# Altium schematic sheet REUN.468349.001_PSU_Board_12B_Interface.SchDoc
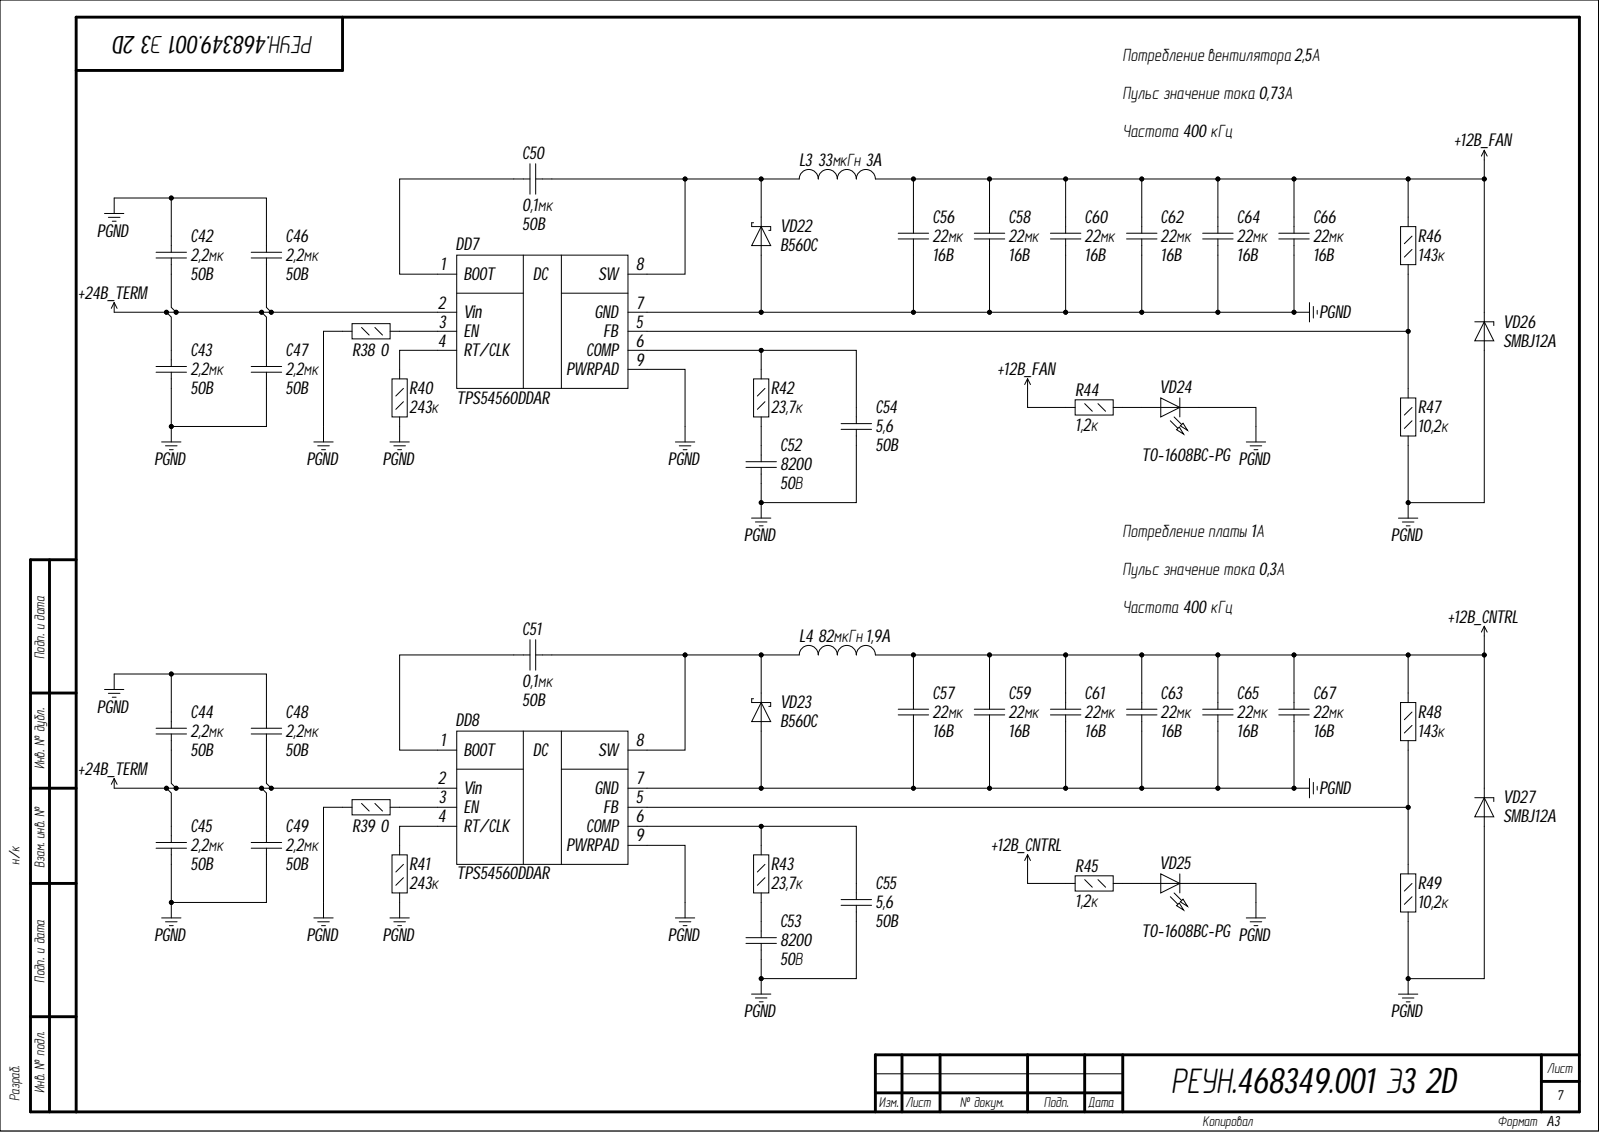
[diagram p0: REUN.468349.001_PSU_Board_12B_Interface.SchDoc]

## Parts
C42  2,2мк  Voltage: 50B  [C1206]
C43  2,2мк  Voltage: 50B  [C1206]
C44  2,2мк  Voltage: 50B  [C1206]
C45  2,2мк  Voltage: 50B  [C1206]
C46  2,2мк  Voltage: 50B  [C1206]
C47  2,2мк  Voltage: 50B  [C1206]
C48  2,2мк  Voltage: 50B  [C1206]
C49  2,2мк  Voltage: 50B  [C1206]
C50  0,1мк  Voltage: 50B  [C0805]
C51  0,1мк  Voltage: 50B  [C0805]
C52  8200  Voltage: 50В  [C0805]
C53  8200  Voltage: 50В  [C0805]
C54  5,6  Voltage: 50B  [C0805]
C55  5,6  Voltage: 50B  [C0805]
C56  22мк  Voltage: 16B  [C1210]
C57  22мк  Voltage: 16B  [C1210]
C58  22мк  Voltage: 16B  [C1210]
C59  22мк  Voltage: 16B  [C1210]
C60  22мк  Voltage: 16B  [C1210]
C61  22мк  Voltage: 16B  [C1210]
C62  22мк  Voltage: 16B  [C1210]
C63  22мк  Voltage: 16B  [C1210]
C64  22мк  Voltage: 16B  [C1210]
C65  22мк  Voltage: 16B  [C1210]
C66  22мк  Voltage: 16B  [C1210]
C67  22мк  Voltage: 16B  [C1210]
DD7  TPS54560DDAR  [SO Powerpad8]
DD8  TPS54560DDAR  [SO Powerpad8]
L3  value 33мкГн  Rated Current: 3A  [IND_12.5x12.5]  (hidden comment: Inductor)
L4  value 82мкГн  Rated Current: 1,9A  [IND_12.5x12.5]  (hidden comment: Inductor)
R38  value 0  [R0805]  (hidden comment: Resistor_0,125Вт)
R39  value 0  [R0805]  (hidden comment: Resistor_0,125Вт)
R40  value 243к  [R0805]  (hidden comment: Resistor_0,125Вт)
R41  value 243к  [R0805]  (hidden comment: Resistor_0,125Вт)
R42  value 23,7к  [R0805]  (hidden comment: Resistor_0,125Вт)
R43  value 23,7к  [R0805]  (hidden comment: Resistor_0,125Вт)
R44  value 1,2к  [R0805]  (hidden comment: Resistor_0,125Вт)
R45  value 1,2к  [R0805]  (hidden comment: Resistor_0,125Вт)
R46  value 143к  [R0805]  (hidden comment: Resistor_0,125Вт)
R47  value 10,2к  [R0805]  (hidden comment: Resistor_0,125Вт)
R48  value 143к  [R0805]  (hidden comment: Resistor_0,125Вт)
R49  value 10,2к  [R0805]  (hidden comment: Resistor_0,125Вт)
VD22  B560C  [SMC]
VD23  B560C  [SMC]
VD24  TO-1608BC-PG  [L_0603_GREEN]
VD25  TO-1608BC-PG  [L_0603_GREEN]
VD26  SMBJ12A  [SMB]
VD27  SMBJ12A  [SMB]

## Nets
+12B_CNTRL: C57.2 C59.2 C61.2 C63.2 C65.2 C67.2 L4.2 R45.2 R48.2 VD27.1
+12B_FAN: C56.2 C58.2 C60.2 C62.2 C64.2 C66.2 L3.2 R44.2 R46.2 VD26.1
+24B_TERM: C42.1 C43.2 C44.1 C45.2 C46.1 C47.2 C48.1 C49.2 DD7.2(Vin) DD8.2(Vin)
PGND: C42.2 C43.1 C44.2 C45.1 C46.2 C47.1 C48.2 C49.1 C52.1 C53.1 C54.1 C55.1 C56.1 C57.1 C58.1 C59.1 C60.1 C61.1 C62.1 C63.1 C64.1 C65.1 C66.1 C67.1 DD7.7(GND) DD7.9(PWRPAD) DD8.7(GND) DD8.9(PWRPAD) R38.2 R39.2 R40.1 R41.1 R47.1 R49.1 VD22.2 VD23.2 VD24.1 VD25.1 VD26.2 VD27.2
NetC50_1: C50.1 DD7.8(SW) L3.1 VD22.1
NetC50_2: C50.2 DD7.1(BOOT)
NetC51_1: C51.1 DD8.8(SW) L4.1 VD23.1
NetC51_2: C51.2 DD8.1(BOOT)
NetC52_2: C52.2 R42.1
NetC53_2: C53.2 R43.1
NetC54_2: C54.2 DD7.6(COMP) R42.2
NetC55_2: C55.2 DD8.6(COMP) R43.2
NetDD7_3: DD7.3(EN) R38.1
NetDD7_4: DD7.4(RT/CLK) R40.2
NetDD7_5: DD7.5(FB) R46.1 R47.2
NetDD8_3: DD8.3(EN) R39.1
NetDD8_4: DD8.4(RT/CLK) R41.2
NetDD8_5: DD8.5(FB) R48.1 R49.2
NetR44_1: R44.1 VD24.2
NetR45_1: R45.1 VD25.2

## Library data of the parts
C42-C49 = cap351: Конденсатор; Manufacture: Samsung; Part Name: MLCC; Part Number: CL31B225KBHNNNE; Database Table Name: Capacitors$; tga: 0.10%; TKE: X7R; Component Kind: Standard; id: cap351; Library Name: MOP44.DbLib; Value: 2,2мк
C50 C51 = cap141: Конденсатор; Manufacture: Murata; Part Name: Ceramic; Part Number: GRM21BR71H104KA01K; Database Table Name: Capacitors$; TKE: X7R; Component Kind: Standard; id: cap141; Library Name: MOP44.DbLib; Value: 0,1мк
C52 C53 = cap171: Конденсатор; Manufacture: Murata; Part Name: Ceramic; Part Number: GRM216R71H822KA01D; Database Table Name: Capacitors$; TKE: X7R; Component Kind: Standard; id: cap171; Library Name: MOP44.DbLib; Value: 8200
C54 C55 = cap355: Конденсатор; Manufacture: Samsung; Part Name: Ceramic; Part Number: CL21C5R6CBANNNC; Database Table Name: Capacitors$; tga: 0.05%; TKE: NP0; Component Kind: Standard; id: cap355; Library Name: MOP44.DbLib; Value: 5,6
C56-C67 = cap352: Конденсатор; Manufacture: Samsung; Part Name: MLCC; Part Number: CL32B226KOJNNNE; Database Table Name: Capacitors$; tga: 0.10%; TKE: X7R; Component Kind: Standard; id: cap352; Library Name: MOP44.DbLib; Value: 22мк
DD7 DD8 = pwr177: 5-A, Wide Input Range, Step-Down Converter; Manufacturer: Texas Instruments; Part Name: Step Down DC-DC Converter with Eco-mode; Current: 5A; Database Table Name: PowerTransducer$; Frequency: 100 kHz-25 MHz; Input Voltage: 4,5-60В; Output Voltage: 0,8B-58,8B; Component Kind: Standard; id: pwr177; Library Name: MOP44.DbLib; Part Number: TPS54560DDAR; Type: Impulse
L3 = ind80: EMI Suppression Filter; Manufacture: Epcos; Part Name: SMD Power Inductors; Part Number: B82477G4333M000; Database Table Name: Inductor$; Resistance: 0,053Ohm; Saturation Current: 3,5A; Component Kind: Standard; id: ind80; Library Name: MOP44.DbLib
L4 = ind83: EMI Suppression Filter; Manufacture: Epcos; Part Name: SMD Power Inductors; Part Number: B82477G4823M000; Database Table Name: Inductor$; Resistance: 0,145Ohm; Saturation Current: 2,25A; Component Kind: Standard; id: ind83; Library Name: MOP44.DbLib
R38 R39 = res25: Резистор; Manufacture: Yageo; Part Number: RC0805FR-070RL; Accuracy: 0.01; id: res49; Component Kind: Standard; Part Name: Resistor; Power: 0,125Вт; Library Name: REUN.468349.001_PSU_Board.SchLib
R40 R41 = res1226: Резистор; Manufacture: Yageo; Part Number: RC0805FR-07243KL; Accuracy: 0.01; Database Table Name: Resistor$; Component Kind: Standard; id: res1226; Library Name: MOP44.DbLib; Part Name: Resistor; Power: 0,125Вт
R42 R43 = res1173: Резистор; Manufacture: Yageo; Part Number: RC0805FR-0723K7L; Accuracy: 0.01; Database Table Name: Resistor$; Component Kind: Standard; id: res1173; Library Name: MOP44.DbLib; Part Name: Resistor; Power: 0,125Вт
R44 R45 = res78: Резистор; Manufacture: Yageo; Part Number: RC0805FR-071K2; Accuracy: 0.01; Database Table Name: Resistor$; Component Kind: Standard; id: res78; Library Name: MOP44.DbLib; Part Name: Resistor; Power: 0,125Вт
R46 R48 = res1253: Резистор; Manufacture: Yageo; Part Number: RC0805FR-07143KL; Accuracy: 0.01; Database Table Name: Resistor$; Component Kind: Standard; id: res1253; Library Name: MOP44.DbLib; Part Name: Resistor; Power: 0,125Вт
R47 R49 = res1230: Резистор; Manufacture: Yageo; Part Number: RC0805FR-0710K2L; Accuracy: 0.01; Database Table Name: Resistor$; Component Kind: Standard; id: res1230; Library Name: MOP44.DbLib; Part Name: Resistor; Power: 0,125Вт
VD22 VD23 = diode32: Диод Шоттки; Manufacture: Diodes Incorporated; Part Name: SCHOTTKY BARRIER RECTIFIER; Capacitance: 300pF; Database Table Name: Diode$; Forward Current: 5A; Forward Voltage: 0,7B; Max Reverse Voltage: 60B; Number: 1; Reverse Current: 20mA; Component Kind: Standard; id: diode32; Library Name: MOP44.DbLib; Part Number: B560C; Temp MAX: +150; Temp MIN: -55
VD24 VD25 = diode43: Светодиод; Manufacture: OASISTEK; Part Name: LED green 0603; Database Table Name: Diode$; Component Kind: Standard; id: diode43; Library Name: MOP44.DbLib; Part Number: TO-1608BC-PG
VD26 VD27 = SMBJ12A: Cтабилитрон (лавинный выпрямительный диод) односторонний; Manufacture: ST Microelectronics; Part Name: TVS diode Unidirectional; Breakdown Voltage: 14B; Clamping voltage: 19,9B; Database Table Name: TVSdiode$; Dynamic resistance: 0,168Ohm; id: tvs73; Leakage Current: 0,2uA; Number of diodes: 1; Peak Pulse Power: 600W; Stand-off Voltage: 12B; Component Kind: Standard; Library Name: MOP44.DbLib; Part Number: SMBJ12A
